# Altium schematic sheet LCD_SCH_ascii.SchDoc(LCD_SCH_ascii)
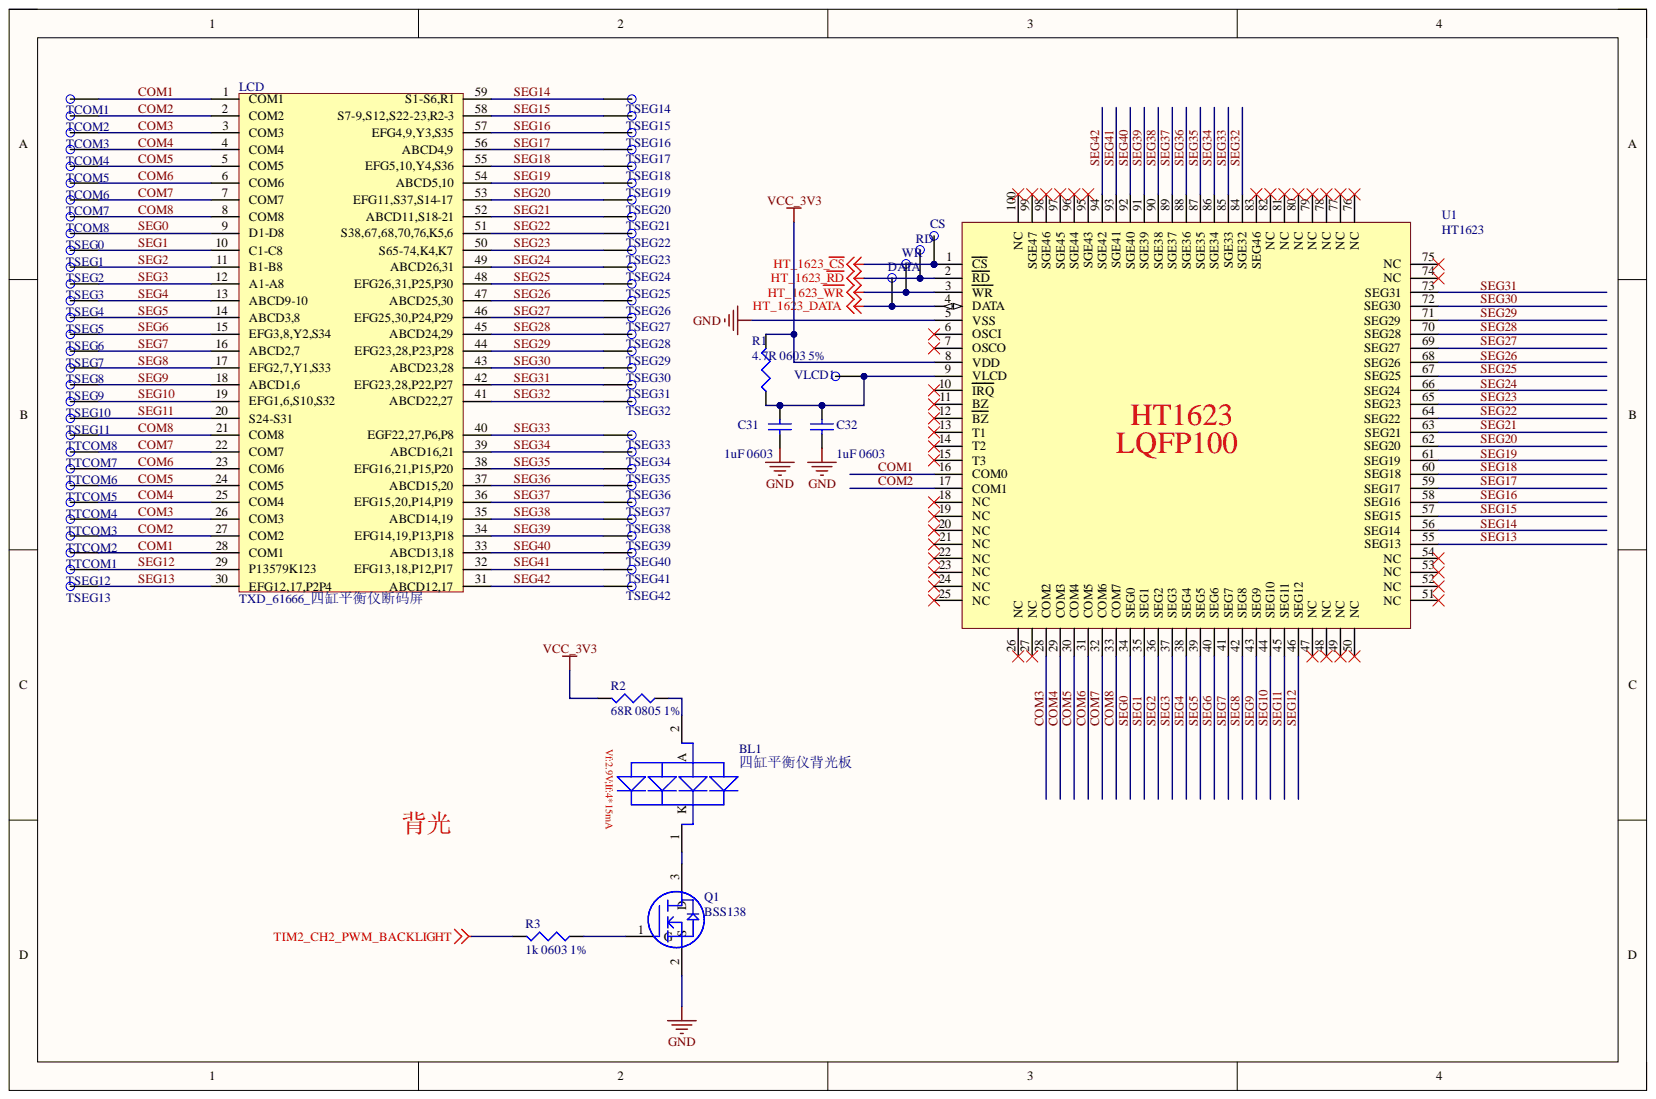
[diagram p0: LCD_SCH_ascii.SchDoc]

## Parts
BL1  四缸平衡仪背光板  [四缸平衡仪背光]
C31  1uF 0603  封装: 0603  [CAP0603_3D]
C32  1uF 0603  封装: 0603  [CAP0603_3D]
CS  TEST-POINT  [tp]  (value not drawn)
DATA  TEST-POINT  [tp]  (value not drawn)
LCD  TXD_61666_四缸平衡仪断码屏  [四缸平衡仪断码屏59PIN]
Q1  BSS138  [SOT23_PMOS_3D]
R1  4.7R 0603 5%  [RES0603_3D]
R2  68R 0805 1%  精度误差: 1%  [RES0805-3D]
R3  1k 0603 1%  精度误差: 1%  [RES0603_3D]
RD  TEST-POINT  [tp]  (value not drawn)
TCOM1  TEST-POINT  [tp]  (value not drawn)
TCOM2  TEST-POINT  [tp]  (value not drawn)
TCOM3  TEST-POINT  [tp]  (value not drawn)
TCOM4  TEST-POINT  [tp]  (value not drawn)
TCOM5  TEST-POINT  [tp]  (value not drawn)
TCOM6  TEST-POINT  [tp]  (value not drawn)
TCOM7  TEST-POINT  [tp]  (value not drawn)
TCOM8  TEST-POINT  [tp]  (value not drawn)
TSEG0  TEST-POINT  [tp]  (value not drawn)
TSEG1  TEST-POINT  [tp]  (value not drawn)
TSEG2  TEST-POINT  [tp]  (value not drawn)
TSEG3  TEST-POINT  [tp]  (value not drawn)
TSEG4  TEST-POINT  [tp]  (value not drawn)
TSEG5  TEST-POINT  [tp]  (value not drawn)
TSEG6  TEST-POINT  [tp]  (value not drawn)
TSEG7  TEST-POINT  [tp]  (value not drawn)
TSEG8  TEST-POINT  [tp]  (value not drawn)
TSEG9  TEST-POINT  [tp]  (value not drawn)
TSEG10  TEST-POINT  [tp]  (value not drawn)
TSEG11  TEST-POINT  [tp]  (value not drawn)
TSEG12  TEST-POINT  [tp]  (value not drawn)
TSEG13  TEST-POINT  [tp]  (value not drawn)
TSEG14  TEST-POINT  [tp]  (value not drawn)
TSEG15  TEST-POINT  [tp]  (value not drawn)
TSEG16  TEST-POINT  [tp]  (value not drawn)
TSEG17  TEST-POINT  [tp]  (value not drawn)
TSEG18  TEST-POINT  [tp]  (value not drawn)
TSEG19  TEST-POINT  [tp]  (value not drawn)
TSEG20  TEST-POINT  [tp]  (value not drawn)
TSEG21  TEST-POINT  [tp]  (value not drawn)
TSEG22  TEST-POINT  [tp]  (value not drawn)
TSEG23  TEST-POINT  [tp]  (value not drawn)
TSEG24  TEST-POINT  [tp]  (value not drawn)
TSEG25  TEST-POINT  [tp]  (value not drawn)
TSEG26  TEST-POINT  [tp]  (value not drawn)
TSEG27  TEST-POINT  [tp]  (value not drawn)
TSEG28  TEST-POINT  [tp]  (value not drawn)
TSEG29  TEST-POINT  [tp]  (value not drawn)
TSEG30  TEST-POINT  [tp]  (value not drawn)
TSEG31  TEST-POINT  [tp]  (value not drawn)
TSEG32  TEST-POINT  [tp]  (value not drawn)
TSEG33  TEST-POINT  [tp]  (value not drawn)
TSEG34  TEST-POINT  [tp]  (value not drawn)
TSEG35  TEST-POINT  [tp]  (value not drawn)
TSEG36  TEST-POINT  [tp]  (value not drawn)
TSEG37  TEST-POINT  [tp]  (value not drawn)
TSEG38  TEST-POINT  [tp]  (value not drawn)
TSEG39  TEST-POINT  [tp]  (value not drawn)
TSEG40  TEST-POINT  [tp]  (value not drawn)
TSEG41  TEST-POINT  [tp]  (value not drawn)
TSEG42  TEST-POINT  [tp]  (value not drawn)
TTCOM1  TEST-POINT  [tp]  (value not drawn)
TTCOM2  TEST-POINT  [tp]  (value not drawn)
TTCOM3  TEST-POINT  [tp]  (value not drawn)
TTCOM4  TEST-POINT  [tp]  (value not drawn)
TTCOM5  TEST-POINT  [tp]  (value not drawn)
TTCOM6  TEST-POINT  [tp]  (value not drawn)
TTCOM7  TEST-POINT  [tp]  (value not drawn)
TTCOM8  TEST-POINT  [tp]  (value not drawn)
U1  HT1623  [LQFP100_N]
VLCD1  TEST-POINT  [tp]  (value not drawn)
WR  TEST-POINT  [tp]  (value not drawn)

## Nets
GND: C31.1 C32.1 Q1.2 U1.5(VSS)
HT_1623_~{CS}: CS.1 U1.1(CS)
HT_1623_DATA: DATA.1 U1.4(DATA)
HT_1623_~{RD}: RD.1 U1.2(RD)
HT_1623_~{WR}: U1.3(WR) WR.1
TIM2_CH2_PWM_BACKLIGHT: R3.2
VCC_3V3: R1.2 R2.2 U1.8(VDD)
COM1: LCD.1(COM1) LCD.28(COM1) TCOM1.1 TTCOM1.1 U1.16(COM0)
COM2: LCD.2(COM2) LCD.27(COM2) TCOM2.1 TTCOM2.1 U1.17(COM1)
COM3: LCD.3(COM3) LCD.26(COM3) TCOM3.1 TTCOM3.1 U1.28(COM2)
COM4: LCD.4(COM4) LCD.25(COM4) TCOM4.1 TTCOM4.1 U1.29(COM3)
COM5: LCD.5(COM5) LCD.24(COM5) TCOM5.1 TTCOM5.1 U1.30(COM4)
COM6: LCD.6(COM6) LCD.23(COM6) TCOM6.1 TTCOM6.1 U1.31(COM5)
COM7: LCD.7(COM7) LCD.22(COM7) TCOM7.1 TTCOM7.1 U1.32(COM6)
COM8: LCD.8(COM8) LCD.21(COM8) TCOM8.1 TTCOM8.1 U1.33(COM7)
SEG0: LCD.9(D1-D8) TSEG0.1 U1.34(SEG0)
SEG1: LCD.10(C1-C8) TSEG1.1 U1.35(SEG1)
SEG2: LCD.11(B1-B8) TSEG2.1 U1.36(SEG2)
SEG3: LCD.12(A1-A8) TSEG3.1 U1.37(SEG3)
SEG4: LCD.13(ABCD9-10) TSEG4.1 U1.38(SEG4)
SEG5: LCD.14(ABCD3,8) TSEG5.1 U1.39(SEG5)
SEG6: LCD.15(EFG3,8,Y2,S34) TSEG6.1 U1.40(SEG6)
SEG7: LCD.16(ABCD2,7) TSEG7.1 U1.41(SEG7)
SEG8: LCD.17(EFG2,7,Y1,S33) TSEG8.1 U1.42(SEG8)
SEG9: LCD.18(ABCD1,6) TSEG9.1 U1.43(SEG9)
SEG10: LCD.19(EFG1,6,S10,S32) TSEG10.1 U1.44(SEG10)
SEG11: LCD.20(S24-S31) TSEG11.1 U1.45(SEG11)
SEG12: LCD.29(P13579K123) TSEG12.1 U1.46(SEG12)
SEG13: LCD.30(EFG12,17,P2P4) TSEG13.1 U1.55(SEG13)
SEG14: LCD.59(S1-S6,R1) TSEG14.1 U1.56(SEG14)
SEG15: LCD.58(S7-9,S12,S22-23,R2-3) TSEG15.1 U1.57(SEG15)
SEG16: LCD.57(EFG4,9,Y3,S35) TSEG16.1 U1.58(SEG16)
SEG17: LCD.56(ABCD4,9) TSEG17.1 U1.59(SEG17)
SEG18: LCD.55(EFG5,10,Y4,S36) TSEG18.1 U1.60(SEG18)
SEG19: LCD.54(ABCD5,10) TSEG19.1 U1.61(SEG19)
SEG20: LCD.53(EFG11,S37,S14-17) TSEG20.1 U1.62(SEG20)
SEG21: LCD.52(ABCD11,S18-21) TSEG21.1 U1.63(SEG21)
SEG22: LCD.51(S38,67,68,70,76,K5,6) TSEG22.1 U1.64(SEG22)
SEG23: LCD.50(S65-74,K4,K7) TSEG23.1 U1.65(SEG23)
SEG24: LCD.49(ABCD26,31) TSEG24.1 U1.66(SEG24)
SEG25: LCD.48(EFG26,31,P25,P30) TSEG25.1 U1.67(SEG25)
SEG26: LCD.47(ABCD25,30) TSEG26.1 U1.68(SEG26)
SEG27: LCD.46(EFG25,30,P24,P29) TSEG27.1 U1.69(SEG27)
SEG28: LCD.45(ABCD24,29) TSEG28.1 U1.70(SEG28)
SEG29: LCD.44(EFG23,28,P23,P28) TSEG29.1 U1.71(SEG29)
SEG30: LCD.43(ABCD23,28) TSEG30.1 U1.72(SEG30)
SEG31: LCD.42(EFG23,28,P22,P27) TSEG31.1 U1.73(SEG31)
SEG32: LCD.41(ABCD22,27) TSEG32.1 U1.84(SGE32)
SEG33: LCD.40(EGF22,27,P6,P8) TSEG33.1 U1.85(SGE33)
SEG34: LCD.39(ABCD16,21) TSEG34.1 U1.86(SGE34)
SEG35: LCD.38(EFG16,21,P15,P20) TSEG35.1 U1.87(SGE35)
SEG36: LCD.37(ABCD15,20) TSEG36.1 U1.88(SGE36)
SEG37: LCD.36(EFG15,20,P14,P19) TSEG37.1 U1.89(SGE37)
SEG38: LCD.35(ABCD14,19) TSEG38.1 U1.90(SGE38)
SEG39: LCD.34(EFG14,19,P13,P18) TSEG39.1 U1.91(SGE39)
SEG40: LCD.33(ABCD13,18) TSEG40.1 U1.92(SGE40)
SEG41: LCD.32(EFG13,18,P12,P17) TSEG41.1 U1.93(SGE41)
SEG42: LCD.31(ABCD12,17) TSEG42.1 U1.94(SGE42)
NetBL1_1: BL1.1 Q1.3
NetBL1_2: BL1.2 R2.1
NetC31_2: C31.2 C32.2 R1.1 U1.9(VLCD) VLCD1.1
NetQ1_1: Q1.1 R3.1

Not connected: U1 6(OSCI) 7(OSCO) 10(IRQ) 11(BZ) 12(BZ) 13(T1) 14(T2) 15(T3) 18(NC) 19(NC) 20(NC) 21(NC) 22(NC) 23(NC) 24(NC) 25(NC) 26(NC) 27(NC) 47(NC) 48(NC) 49(NC) 50(NC) 51(NC) 52(NC) 53(NC) 54(NC) 74(NC) 75(NC) 76(NC) 77(NC) 78(NC) 79(NC) 80(NC) 81(NC) 82(NC) 83(SEG46) 95(SGE43) 96(SGE44) 97(SGE45) 98(SGE46) 99(SGE47) 100(NC)

## Library data of the parts
C31 C32 = CAP_SMT: 贴片多层陶瓷电容; 容值误差: 10%; 耐压: 50V; Component Kind: Standard; Library Name: hwt.SchLib
R1 = RES_SMT: 精度误差: 1%; Component Kind: Standard; Library Name: hwt.SchLib
R2 R3 = RES_SMT: Component Kind: Standard; Library Name: hwt.SchLib
BL1 = 四缸平衡仪背光板: Component Kind: Standard; Library Name: hwt.SchLib
CS DATA RD TCOM1-TCOM8 TSEG0-TSEG42 TTCOM1-TTCOM8 VLCD1 WR = TEST-POINT: Component Kind: Standard; Library Name: hwt.SchLib
LCD = TXD_61666_四缸平衡仪断码屏: Component Kind: Standard; Library Name: hwt.SchLib
Q1 = BSS138: Component Kind: Standard; Library Name: hwt.SchLib
U1 = HT1623: Component Kind: Standard; Library Name: hwt.SchLib
